# Altium schematic sheet 01_Ethernet.SchDoc
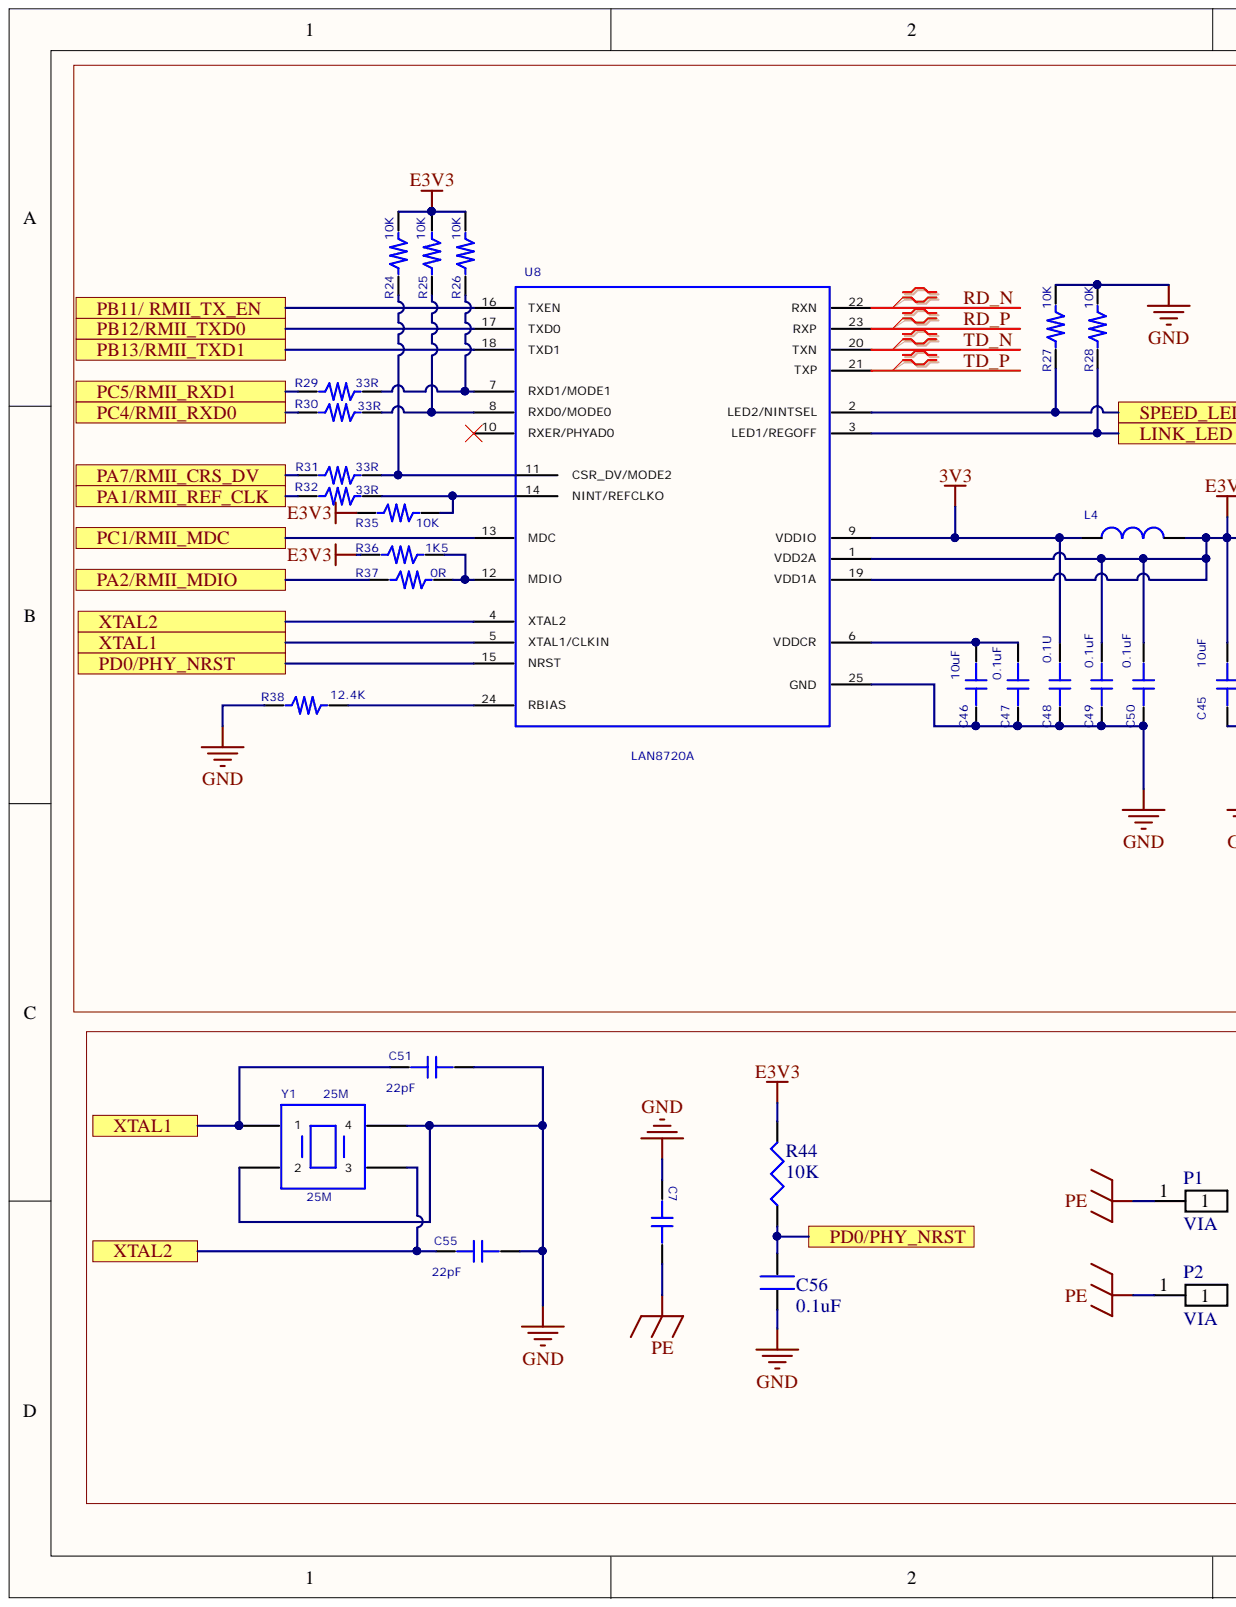
[diagram p0: 01_Ethernet.SchDoc, left]
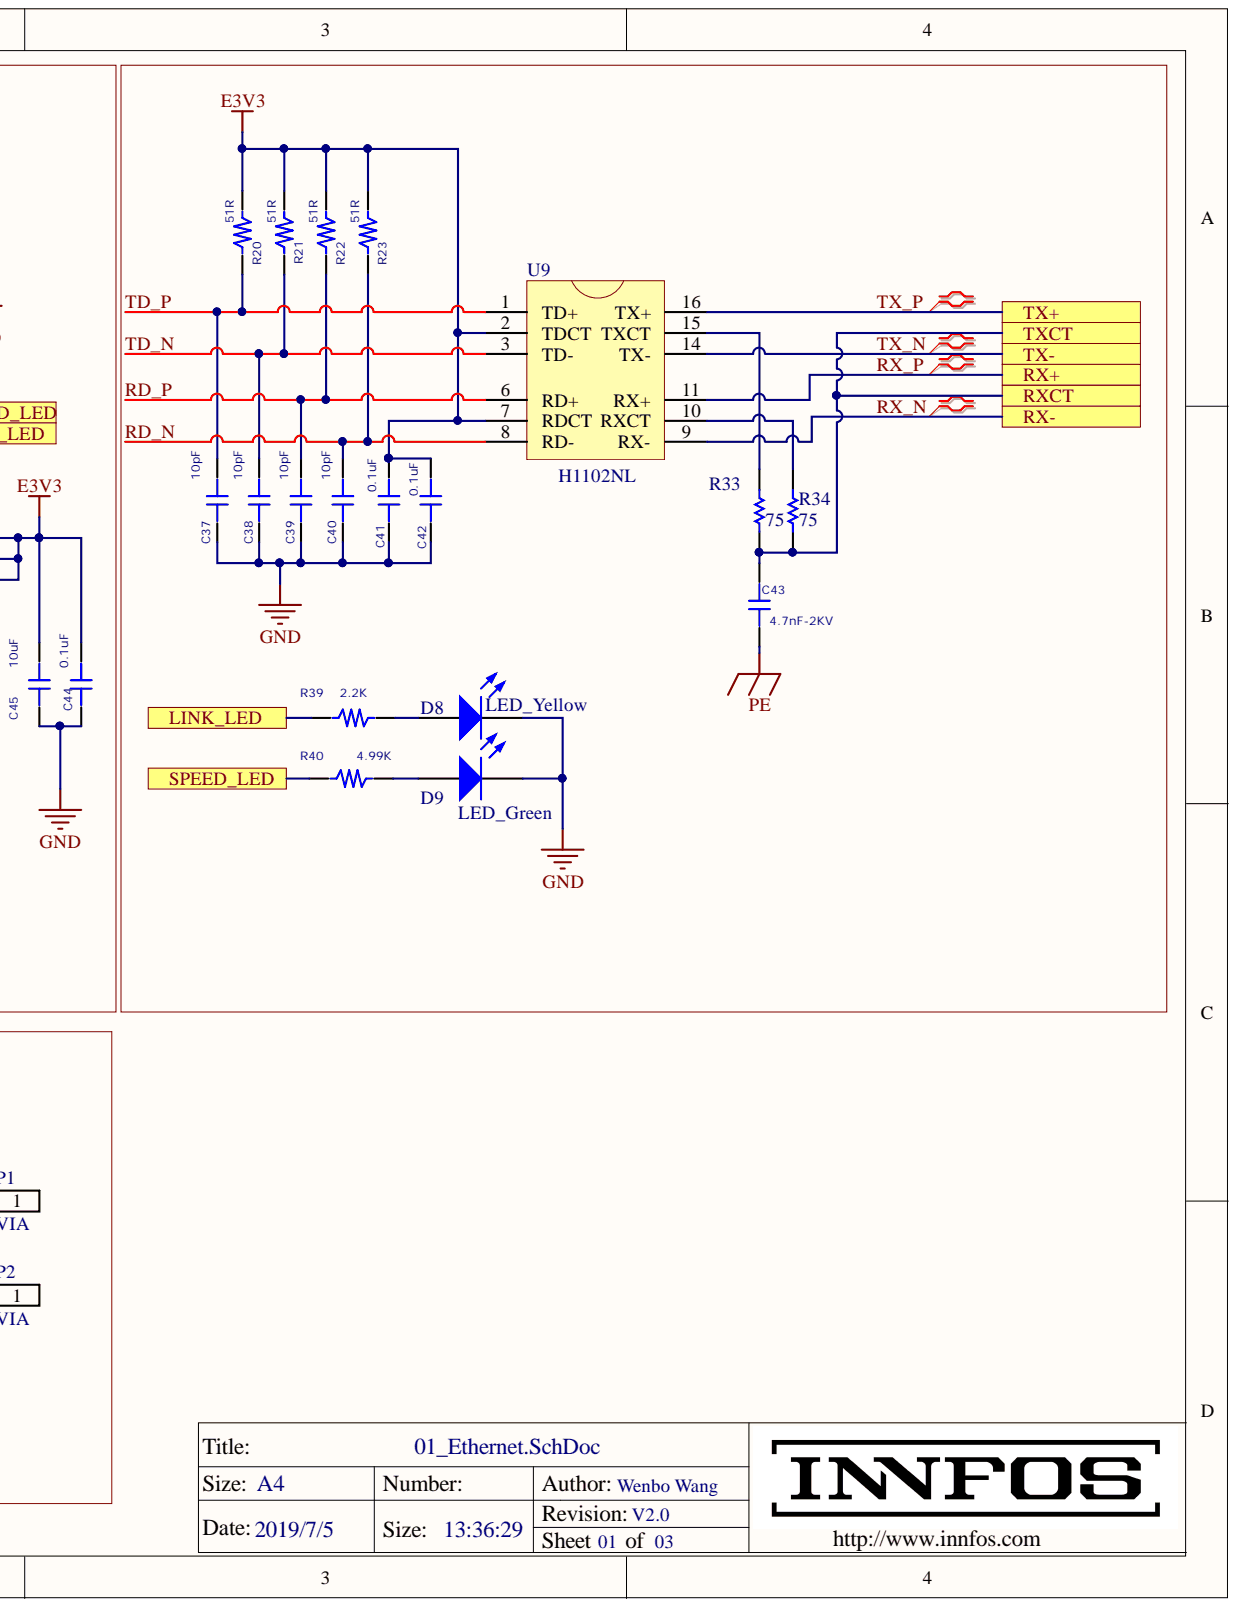
[diagram p1: 01_Ethernet.SchDoc, right]

## Parts
C7  47nF  [0805]  (value not drawn)
C37  10pF  [0402]
C38  10pF  [0402]
C39  10pF  [0402]
C40  10pF  [0402]
C41  0.1uF  [0402]
C42  0.1uF  [0402]
C43  4.7nF-2KV  [1206]
C44  0.1uF  [0402]
C45  10uF  [0402]
C46  10uF  [0402]
C47  0.1uF  [0402]
C48  0.1uF  value 0.1U  [0402]
C49  0.1uF  [0402]
C50  0.1uF  [0402]
C51  22pF  [0402]
C55  22pF  [0402]
C56  0.1uF  [0402]
D8  LED_Yellow  [LED_0603_ORG]
D9  LED_Green  [LED_0603_GREE]
L4  BLM15PG100SN1  [0402]  (value not drawn)
P1  VIA  [via_1_0]
P2  VIA  [via_1_0]
R20  51R  [0402]
R21  51R  [0402]
R22  51R  [0402]
R23  51R  [0402]
R24  10K  [0402]  (no BOM)
R25  10K  [0402]  (no BOM)
R26  10K  [0402]  (no BOM)
R27  10K  [0402]
R28  10K  [0402]
R29  33R  [0402]
R30  33R  [0402]
R31  33R  [0402]
R32  33R  [0402]
R33  75  [0402]
R34  75  [0402]
R35  10K  [0402]  (no BOM)
R36  1K5  [0402]  (no BOM)
R37  0R  [0402]
R38  12.4K  [0402]
R39  2.2K  [0402]
R40  4.99K  [0402]
R44  10K  [0402]
U8  LAN8720A  [QFN_24]
U9  H1102NL  [H1102NL]
Y1  25M  [X1_3225]

## Ports
LINK_LED, PA1/RMII_REF_CLK, PA2/RMII_MDIO, PA7/RMII_CRS_DV, PB11/ RMII_TX_EN, PB12/RMII_TXD0, PB13/RMII_TXD1, PC1/RMII_MDC, PC4/RMII_RXD0, PC5/RMII_RXD1, PD0/PHY_NRST, RX+, RX-, RXCT, SPEED_LED, TX+, TX-, TXCT, XTAL1, XTAL2

## Nets
3V3: C48.2 L4.1 U8.9(VDDIO)
E3V3: C41.2 C42.2 C44.2 C45.2 C49.2 C50.2 L4.2 R20.2 R21.2 R22.2 R23.2 R24.2 R25.2 R26.2 R35.2 R36.2 R44.2 U8.1(VDD2A) U8.19(VDD1A) U9.2(TDCT) U9.7(RDCT)
GND: C7.1 C37.1 C38.1 C39.1 C40.1 C41.1 C42.1 C44.1 C45.1 C46.1 C47.1 C48.1 C49.1 C50.1 C51.2 C55.2 C56.1 D8.2 D9.2 R27.2 R28.2 R38.1 U8.25(GND) Y1.2 Y1.4
PE: C7.2 C43.1 P1.1 P2.1
LINK_LED: R28.1 R39.2 U8.3(LED1/REGOFF) ; port LINK_LED
PA1/RMII_REF_CLK: R32.1 ; port PA1/RMII_REF_CLK
PA2/RMII_MDIO: R37.1 ; port PA2/RMII_MDIO
PA7/RMII_CRS_DV: R31.1 ; port PA7/RMII_CRS_DV
PB11/ RMII_TX_EN: U8.16(TXEN) ; port PB11/ RMII_TX_EN
PB12/RMII_TXD0: U8.17(TXD0) ; port PB12/RMII_TXD0
PB13/RMII_TXD1: U8.18(TXD1) ; port PB13/RMII_TXD1
PC1/RMII_MDC: U8.13(MDC) ; port PC1/RMII_MDC
PC4/RMII_RXD0: R30.1 ; port PC4/RMII_RXD0
PC5/RMII_RXD1: R29.1 ; port PC5/RMII_RXD1
PD0/PHY_NRST: C56.2 R44.1 U8.15(NRST) ; port PD0/PHY_NRST
RD_N: C40.2 R23.1 U8.22(RXN) U9.8(RD-)
RD_P: C39.2 R22.1 U8.23(RXP) U9.6(RD+)
RX_N: U9.9(RX-)
RX_P: U9.11(RX+)
RXCT: C43.2 R33.1 R34.1 ; port RXCT, port TXCT
SPEED_LED: R27.1 R40.2 U8.2(LED2/NINTSEL) ; port SPEED_LED
TD_N: C38.2 R21.1 U8.20(TXN) U9.3(TD-)
TD_P: C37.2 R20.1 U8.21(TXP) U9.1(TD+)
TX_N: U9.14(TX-)
TX_P: U9.16(TX+)
XTAL1: C51.1 U8.5(XTAL1/CLKIN) Y1.1 ; port XTAL1
XTAL2: C55.1 U8.4(XTAL2) Y1.3 ; port XTAL2
NetC46_2: C46.2 C47.2 U8.6(VDDCR)
NetD8_1: D8.1 R39.1
NetD9_1: D9.1 R40.1
NetR24_1: R24.1 R31.2 U8.11(CSR_DV/MODE2)
NetR25_1: R25.1 R30.2 U8.8(RXD0/MODE0)
NetR26_1: R26.1 R29.2 U8.7(RXD1/MODE1)
NetR32_2: R32.2 R35.1 U8.14(NINT/REFCLKO)
NetR33_2: R33.2 U9.15(TXCT)
NetR34_2: R34.2 U9.10(RXCT)
NetR36_1: R36.1 R37.2 U8.12(MDIO)
NetR38_2: R38.2 U8.24(RBIAS)

Not connected: U8 10(RXER/PHYAD0)

## Library data of the parts
C7 = C_4.7NF_1206: PCB DECAL: 1206C; Value: 4.7nF-2KV; Component Kind: Standard; Library Name: F429.SchLib
C37-C40 = C_10P_0603: PCB DECAL: C_0603; Value: 10P; Component Kind: Standard; Library Name: F429.SchLib
C41 C42 C44 C47 C49 C50 = C_0.1UF_0603: PCB DECAL: C_0603; Value: 0.1U; Component Kind: Standard; Library Name: F429.SchLib
C43 = C_4.7NF_1206: PCB DECAL: 1206C; Component Kind: Standard; Library Name: F429.SchLib; Value: 4.7nF-2KV
C45 C46 = C_10UF_0603: PCB DECAL: C_0603; Value: 10U; Component Kind: Standard; Library Name: F429.SchLib
C48 = C_0.1UF_0603: PCB DECAL: C_0603; Component Kind: Standard; Library Name: F429.SchLib
C51 C55 = C_22P_0603: PCB DECAL: C_0603; Value: 22P; Component Kind: Standard; Library Name: F429.SchLib
C56 = Cap: Component Kind: Standard; Library Name: My_Schlib.SchLib; Value: 0.1uF
D8 D9 = LED(0603): Component Kind: Standard; Library Name: MY_INTEGRATED_LIBRARY.IntLib
L4 = L-0603: PCB DECAL: 0603; Component Kind: Standard; Library Name: F429.SchLib
P1 P2 = Banana_female: Component Kind: Standard; Library Name: My_Schlib.SchLib
R20-R23 = R_51R_0603: PCB DECAL: R_0603; Component Kind: Standard; Library Name: F429.SchLib; Value: 51R
R24-R28 R35 = R_10K_0603: PCB DECAL: R_0603; Library Name: F429.SchLib; Value: 10K
  R27 R28: Component Kind: Standard
R29-R32 R36 = R_10K_0603: PCB DECAL: R_0603; Value: 10K; Library Name: F429.SchLib
  R29-R32: Component Kind: Standard
R33 R34 = Res_75_1%_0603: Resistor,75,0603,1%,YAGEO; Manuf_Part_1: RC0402FR-0750RL; Value: 50; Component Kind: Standard; Distrib: FARNELL; ECCN_US/EU: n/a; Library Name: MB1137.SCHLIB; Manuf_1: YAGEO
R37 R39 = R_510R_0603: PCB DECAL: R_0603; Value: 510R; Component Kind: Standard; Library Name: F429.SchLib
R38 = R_12.4K_0603: PCB DECAL: R_0603; Component Kind: Standard; Library Name: F429.SchLib; Value: 12.4K
R40 = R_510R_0603: PCB DECAL: R_0603; Value: 3K; Component Kind: Standard; Library Name: F429.SchLib
R44 = Res: Component Kind: Standard; Library Name: My_Schlib.SchLib; PCB3D: Model Name; Value: 10K
U8 = LAN8720A: PCB DECAL: QFN24; Component Kind: Standard; Library Name: F429.SchLib
Y1 = XTAL_25M_3225: PCB DECAL: 3225; Component Kind: Standard; Library Name: F429.SchLib; Value: 25M
U9 = H1102NL: Component Kind: Standard; Library Name: BXXJS-S.SchLib
